# Altium schematic sheet AQM with esp32.SchDoc("AQM with esp32")
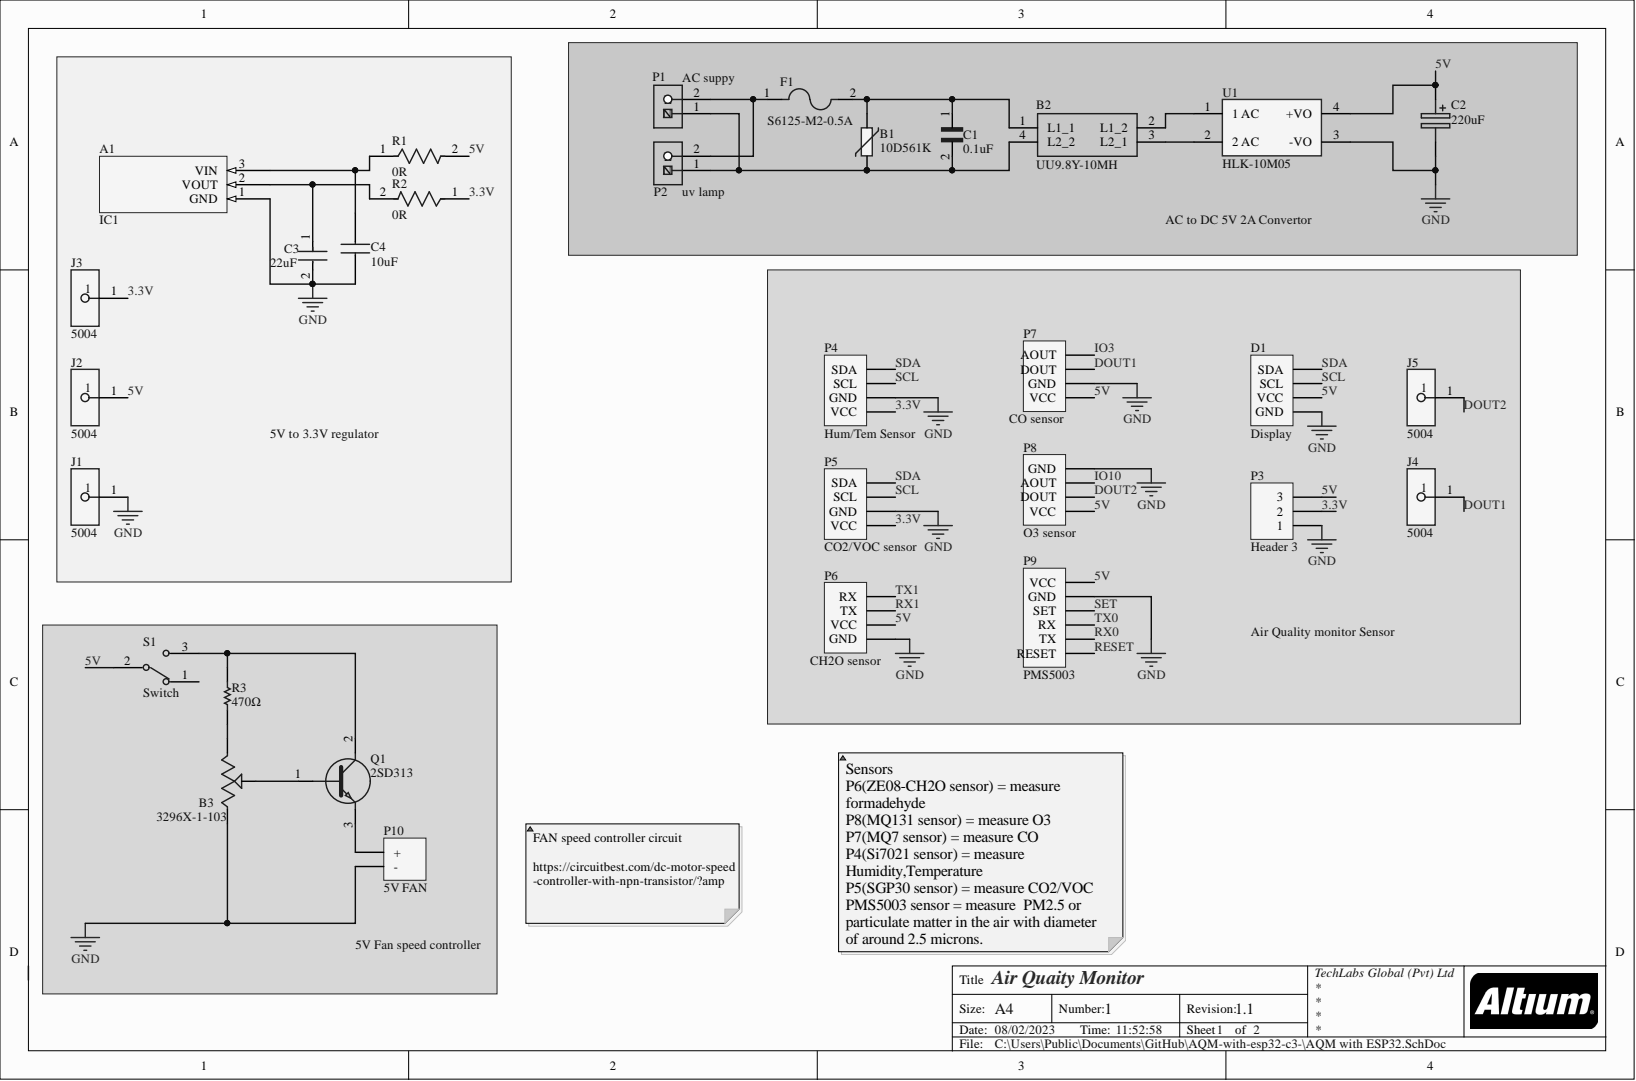
[diagram p0: AQM with esp32.SchDoc]

## Parts
A1  IC1  [SOT89-150P350X150-3N]
B1  10D561K  [10D561K]
B2  UU9.8Y-10MH  [UU98Y10MH]
B3  3296X-1-103  [3296X1223LF]
C1  0.1uF  [MPX-104K275VAC-C-D2]
C2  220uF  [CAPAE830X1050N]
C3  22uF  [FP-GRM219-0_2-0_15-IPC_A]
C4  10uF  [CL05A106MQ5NUNC]
D1  Display  [HDR1X4]
F1  S6125-M2-0.5A  [CAPC6125X25N]
J1  5004  [FP-5004-MFG]
J2  5004  [FP-5004-MFG]
J3  5004  [FP-5004-MFG]
J4  5004  [FP-5004-MFG]
J5  5004  [FP-5004-MFG]
P1  AC suppy  [PHOE-1729128-2_V]
P2  uv lamp  [PHOE-1729128-2_V]
P3  Header 3  [HDR1X3]
P4  Hum/Tem Sensor  [HDR1X4]
P5  CO2/VOC sensor  [HDR1X4]
P6  CH2O sensor  [HDR1X4]
P7  CO sensor  [HDR1X4]
P8  O3 sensor  [HDR1X4]
P9  PMS5003  [HDR1X6]
P10  5V FAN  [HDR1X2]
Q1  2SD313  [TO304P498X1010X2089-3P]
R1  0R  [FP-RC0402-0_4-IPC_A]
R2  0R  [FP-RC0402-0_4-IPC_A]
R3  470Ω  [RESC1608X55X25LL10T15]
S1  Switch  [SK3245SL1A]
U1  HLK-10M05  [FP-HLK-10M05-MFG]

## Nets
GND: A1.1 B3.1 C2.2 C3.2 C4.1 D1.1 J1.1 P3.1 P4.2 P5.2 P6.1 P7.2 P8.4 P9.5 P10.2 U1.3
3.3V: J3.1 P3.2 P4.1 P5.1 R2.1
5V: C2.1 D1.2 J2.1 P3.3 P6.2 P7.1 P8.1 P9.6 R1.2 S1.2 U1.4
DOUT1: J4.1 P7.3
DOUT2: J5.1 P8.2
IO3: P7.4
IO10: P8.3
RESET: P9.1
RX0: P9.2
RX1: P6.3
SCL: D1.3 P4.3 P5.3
SDA: D1.4 P4.4 P5.4
SET: P9.4
TX0: P9.3
TX1: P6.4
NetA1_2: A1.2 C3.1 R2.2
NetA1_3: A1.3 C4.2 R1.1
NetB1_1: B1.1 B2.1 C1.1 F1.2
NetB1_2: B1.2 B2.4 C1.2 P1.1 P2.1
NetB2_2: B2.2 U1.1
NetB2_3: B2.3 U1.2
NetB3_2: B3.2 Q1.1
NetB3_3: B3.3 R3.1
NetF1_1: F1.1 P1.2 P2.2
NetP10_1: P10.1 Q1.3
NetQ1_2: Q1.2 R3.2 S1.3
N00008:

Not connected: S1 1

## Library data of the parts
A1 = IC?: 75dB@(120Hz) 1A 1.25V@(1A) ±125℃@(Tj) Fixed 3.3V~3.3V Positive 1 20V SOT-89 Linear Voltage Regulators (LDO) ROHS; Manufacturer: YONGYUTAI; Mfr. Part #: AMS1117-3.3; Component Kind: Standard; Datasheet: https://datasheet.lcsc.com/lcsc/2110121330_YONGYUTAI-AMS1117-3-3_C2904742.pdf; LCSC Part #: C2904742; Library Name: AMS1117-3.3.SchLib; Package: SOT-89
B1 = 10D561K: 920V 42.3J 180pF@1kHz 460V 350V 504V~616V 2.5kA Plugin Varistors ROHS; Manufacturer: Hongzhi Elec; Component Kind: Standard; Datasheet: https://datasheet.lcsc.com/lcsc/1811141751_Hongzhi-Elec-10D561K_C113236.pdf; LCSC Part #: C113236; Library Name: 10D561K.SchLib; Mfr. Part #: 10D561K; Package: Plugin
B2 = UU9.8Y-10MH: 10mH@1kHz 1.2Ω 2 500mA 50V Plugin Common Mode Filters ROHS; Manufacturer: FH (Guangdong Fenghua Advanced Tech); Component Kind: Standard; Datasheet: https://datasheet.lcsc.com/lcsc/1810311213_FH--Guangdong-Fenghua-Advanced-Tech-UU9-8Y-10mH_C75192.pdf; LCSC Part #: C75192; Library Name: UU9.8Y-10MH.SchLib; Mfr. Part #: UU9.8Y-10MH; Package: Plugin
B3 = 3296X-1-103: ±10% 10kΩ Plugin Variable Resistors/Potentiometers ROHS; Manufacturer: BOCHEN(Chengdu Guosheng Tech); Component Kind: Standard; Datasheet: https://datasheet.lcsc.com/lcsc/1811011704_BOCHEN-Chengdu-Guosheng-Tech-3296X-1-103_C118955.pdf; LCSC Part #: C118955; Library Name: 3296X-1-103.SchLib; Mfr. Part #: 3296X-1-103; Package: Plugin
C1 = MPX-104K275VAC-C-D2: 0.1uF ±10% -40℃~+110℃ X2 275VAC Radial Leaded,P=15mm Suppression Capacitors ROHS; Manufacturer: CORETONG; Component Kind: Standard; Datasheet: https://datasheet.lcsc.com/lcsc/2207051802_CORETONG-MPX-104K275VAC-C-D2_C3249976.pdf; LCSC Part #: C3249976; Library Name: MPX-104K275VAC-C-D2.SchLib; Mfr. Part #: MPX-104K275VAC-C-D2; Package: Radial Leaded,P=15mm; Value: 0.1uF
C2 = UUR1C221MNL1GS: -40℃~+85℃ 2000hrs@85℃ 220uF 10mm 16V 8mm 215mA@120Hz ±20% SMD,D8xL10mm Aluminum Electrolytic Capacitors - SMD ROHS; Manufacturer: Nichicon; Component Kind: Standard; Datasheet: https://datasheet.lcsc.com/lcsc/2002121532_Nichicon-UUR1C221MNL1GS_C445237.pdf; LCSC Part #: C445237; Library Name: UUR1C221MNL1GS.SchLib; Mfr. Part #: UUR1C221MNL1GS; Package: SMD,D8xL10mm; Value: 220uF
C3 = CMP-06035-056251-1: Chip Multilayer Ceramic Capacitors for General Purpose, 0805, 22uF, X5R, 15%, 20%, 16V; Manufacturer: Murata Electronics; Mfr. Part #: GRM219R61C226ME15L; Component Kind: Standard; Datasheet: https://datasheet.lcsc.com/lcsc/1811141821_Murata-Electronics-GRM219R61C226ME15L_C162295.pdf; LCSC Part #: C162295; Library Name: Altium Content Vault; Package: 0805; value: 22uF
C4 = CL05A106MQ5NUNC: 6.3V 10uF X5R ±20% 0402 Multilayer Ceramic Capacitors MLCC - SMD/SMT ROHS; Manufacturer: Samsung Electro-Mechanics; Component Kind: Standard; Datasheet Link: https://datasheet.datasheetarchive.com/originals/distributors/Datasheets-DGA10/2406160.pdf; LCSC Part #: C15525; Library Name: CL05A106MQ5NUNC.SchLib; Mfr. Part #: CL05A106MQ5NUNC; Package: 0402; value: 10uF
D1 = Header 4: Header, 4-Pin; Component Kind: Standard; LatestRevisionDate: 17-Jul-2002; LatestRevisionNote: Re-released for DXP Platform.; Library Name: Miscellaneous Connectors.IntLib; Signal Integrity: Connector; Publisher: Altium Limited
F1 = S6125-M2-0.5A: SMD Fuses ROHS; Manufacturer: SART(Nanjing Sart Tech); Component Kind: Standard; Datasheet: https://datasheet.lcsc.com/lcsc/2201242130_SART-Nanjing-Sart-Tech-S6125-M2-0-5A_C845172.pdf; LCSC Part #: C845172; Library Name: S6125-M2-0.5A.SchLib; Mfr. Part #: S6125-M2-0.5A; Package: SMD
J1-J5 = CMP-2000-05575-2: PC TEST POINT MINIATURE YELLOW; Manufacturer: Keystone; Component Kind: Standard; ComponentLink2Description: Datasheet; ComponentLink2URL: https://www.keyelco.com/product-pdf.cfm?p=1313; Datasheet: https://datasheet.lcsc.com/lcsc/2201121200_Keystone-5004_C2906762.pdf; LCSC Part #: C2906762; Library Name: Altium Content Vault; Mfr. Part #: 5004; Package: 5004
P1 P2 = CMP-2000-05815-1: 13.5A 400V Green Straight pin 5.08mm 1 2 Plugin,P=5.08mm Screw terminal ROHS; Manufacturer: Phoenix Contact; Mfr. Part #: 1729128; Component Kind: Standard; Datasheet: https://www.farnell.com/datasheets/2617011.pdf; LCSC Part #: C91153; Library Name: Altium Content Vault; Package: Plugin,P=5.08mm
P3 = Header 3: Header, 3-Pin; Component Kind: Standard; LatestRevisionDate: 17-Jul-2002; LatestRevisionNote: Re-released for DXP Platform.; Library Name: Miscellaneous Connectors.IntLib; Signal Integrity: Connector; Publisher: Altium Limited
P4 = Header 4: Si7021 Header, 4-Pin; Component Kind: Standard; Library Name: Miscellaneous Connectors.IntLib; Signal Integrity: Connector
P5 = Header 4: SGP30 Header, 4-Pin; Component Kind: Standard; LatestRevisionDate: 17-Jul-2002; LatestRevisionNote: Re-released for DXP Platform.; Library Name: Miscellaneous Connectors.IntLib; Signal Integrity: Connector; Publisher: Altium Limited
P6 = Header 4: - Sensor Modules ROHS; Manufacturer: Zhengzhou Winsen Elec Tech; Mfr. Part #: ZE08-CH2O; Component Kind: Standard; LCSC Part #: C141344; Library Name: Miscellaneous Connectors.IntLib; Signal Integrity: Connector
P7 = Header 4: MQ7 Header, 4-Pin; Component Kind: Standard; LatestRevisionDate: 17-Jul-2002; LatestRevisionNote: Re-released for DXP Platform.; Library Name: Miscellaneous Connectors.IntLib; Signal Integrity: Connector; Publisher: Altium Limited
P8 = Header 4: MQ131 Header, 4-Pin; Component Kind: Standard; LatestRevisionDate: 17-Jul-2002; LatestRevisionNote: Re-released for DXP Platform.; Library Name: Miscellaneous Connectors.IntLib; Signal Integrity: Connector; Publisher: Altium Limited
P9 = Header 6: PMS5003 Sensor Modules ROHS; Manufacturer: Beijing Plantower; Component Kind: Standard; Datasheet: https://datasheet.lcsc.com/lcsc/1810251510_Beijing-Plantower-PMS5003_C91431.pdf; LCSC Part #: C91431; Library Name: Miscellaneous Connectors.IntLib; Mfr. Part #: PMS5003; Package: PMS5003; Signal Integrity: Connector
P10 = Header 2: Header, 2-Pin; Component Kind: Standard; LatestRevisionDate: 17-Jul-2002; LatestRevisionNote: Re-released for DXP Platform.; Library Name: Miscellaneous Connectors.IntLib; Signal Integrity: Connector; Publisher: Altium Limited
Q1 = 2SD313: NPN Transistor, TO-220; Manufacturer: Jiangsu Changjing Electronics Technology Co., Ltd.; Component Kind: Standard; Datasheet: https://datasheet.lcsc.com/lcsc/2206081730_Jiangsu-Changjing-Electronics-Technology-Co---Ltd--2SD313_C3031919.pdf; LCSC Part #: C3031919; Library Name: 2SD313.SchLib; Mfr. Part #: 2SD313; Package: TO-220
R1 R2 = CMP-2002-07342-2: RES SMD 0 OHM JUMPER 1/16W 0402; Manufacturer: Yageo; Mfr. Part #: RC0402FR-070RL; Component Kind: Standard; Datasheet: https://www.lcsc.com/product-detail/Chip-Resistor-Surface-Mount_YAGEO-RC0402FR-070RL_C106231.html; LCSC Part #: C106231; Library Name: Altium Content Vault; Package: 0402; value: 0R
R3 = CMP-1659-00035-2: 100mW Thick Film Resistors 75V ±100ppm/℃ ±1% -55℃~+155℃ 470Ω 0603 Chip Resistor - Surface Mount ROHS; Manufacturer: YAGEO; Mfr. Part #: RC0603FR-07470RL; Component Kind: Standard; ComponentLink1Description: Manufacturer URL; ComponentLink1URL: http://www.yageo.com/; ComponentLink2Description: Datasheet; ComponentLink2URL: https://datasheet.ciiva.com/11808/0900766b80f96399-11808177.pdf; Datasheet: https://datasheet.lcsc.com/lcsc/1810161617_YAGEO-RC0603FR-07470RL_C114669.pdf; LCSC Part #: C114669; Library Name: Altium Content Vault; Package: 0603; Value: 470Ω
S1 = SK-3245S-L1-A: Surface Mount 50mA DPDT 3.2mm 12V 9.1mm 12V 3.55mm 50mA 10000 SMDSplicing Rectangular columnar -40℃~+85℃ Black SMD Slide Switches ROHS; Manufacturer: XKB Connectivity; Component Kind: Standard; Datasheet: https://datasheet.lcsc.com/lcsc/2002271841_XKB-Connectivity-SK-3245S-L1-A_C405951.pdf; LCSC Part #: C405951; Library Name: SK-3245S-L1-A.SchLib; Mfr. Part #: SK-3245S-L1-A; Package: SMD
U1 = CMP-218581-000001-1: 5V 2A 90VAC~265VAC 1 120V~350V Isolated Regulated 10W AC-DC 80% Plugin Power Modules ROHS; Manufacturer: HI-LINK; Component Kind: Standard; LCSC Part #: C403745; Library Name: Altium Content Vault; Mfr. Part #: HLK-10M05; Package: Plugin
